# Altium schematic sheet [11] - DIGITAL OUTPUT.SchDoc("[11] - DIGITAL OUTPUT")
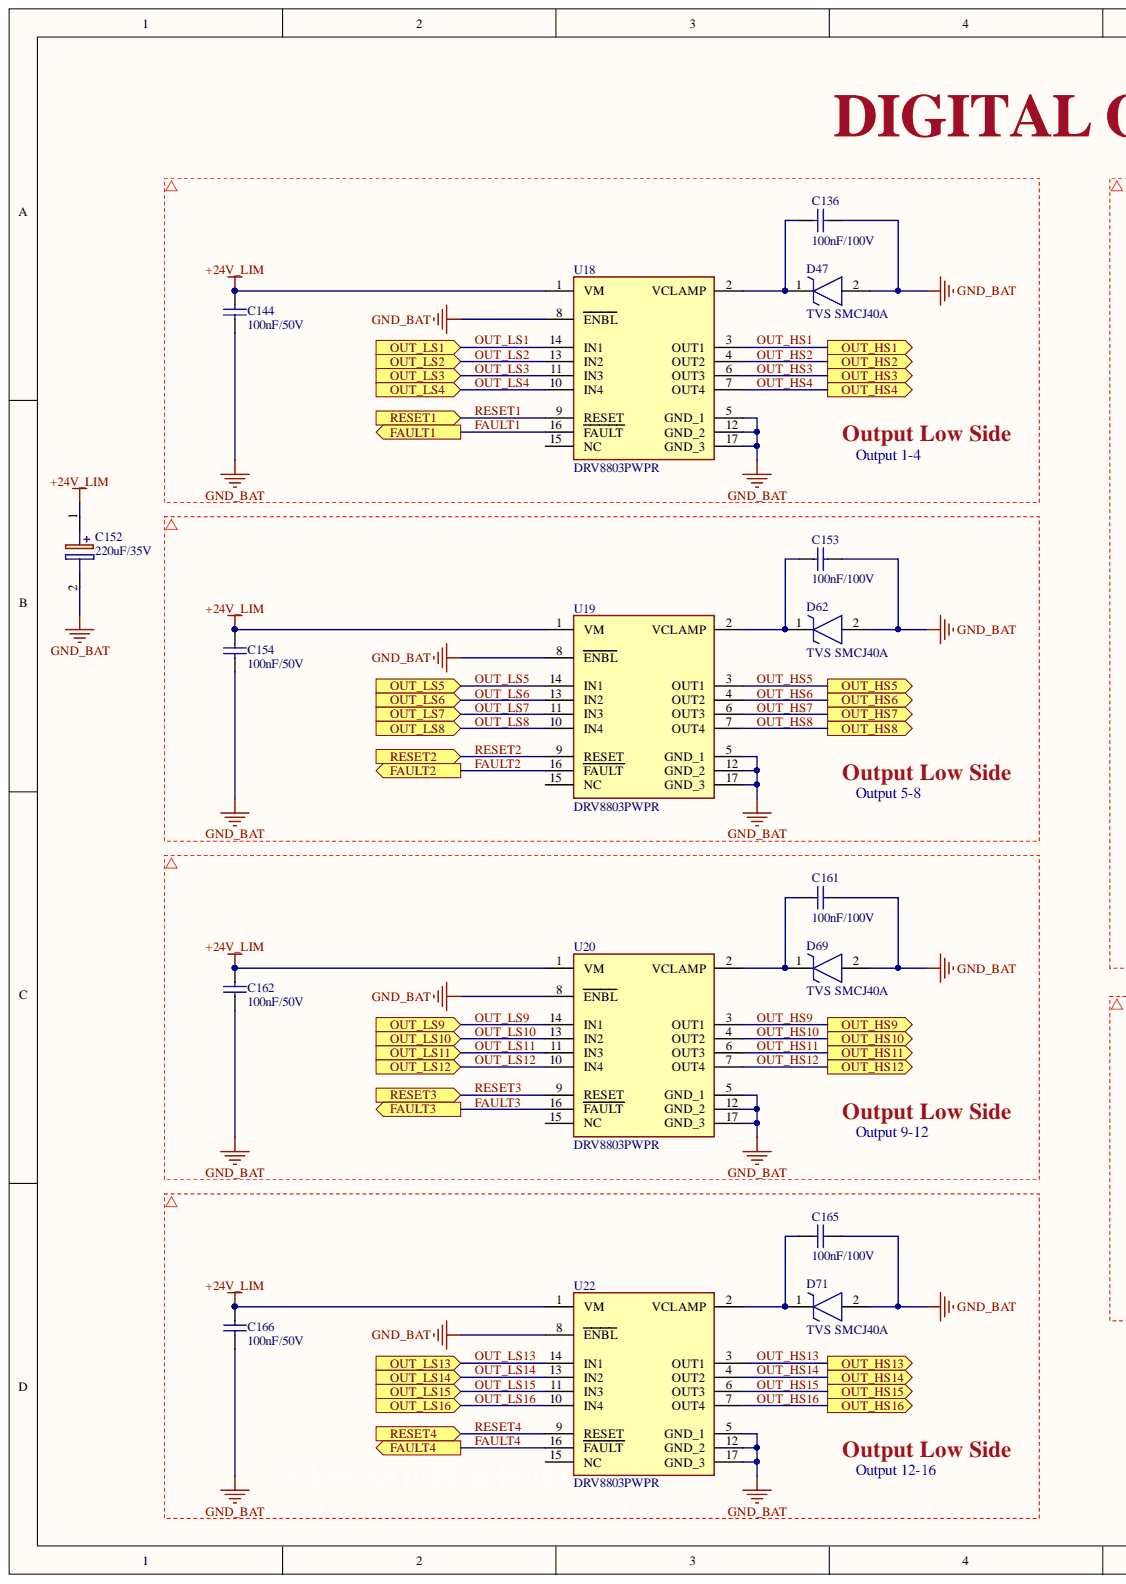
[diagram p0: [11] - DIGITAL OUTPUT.SchDoc, left]
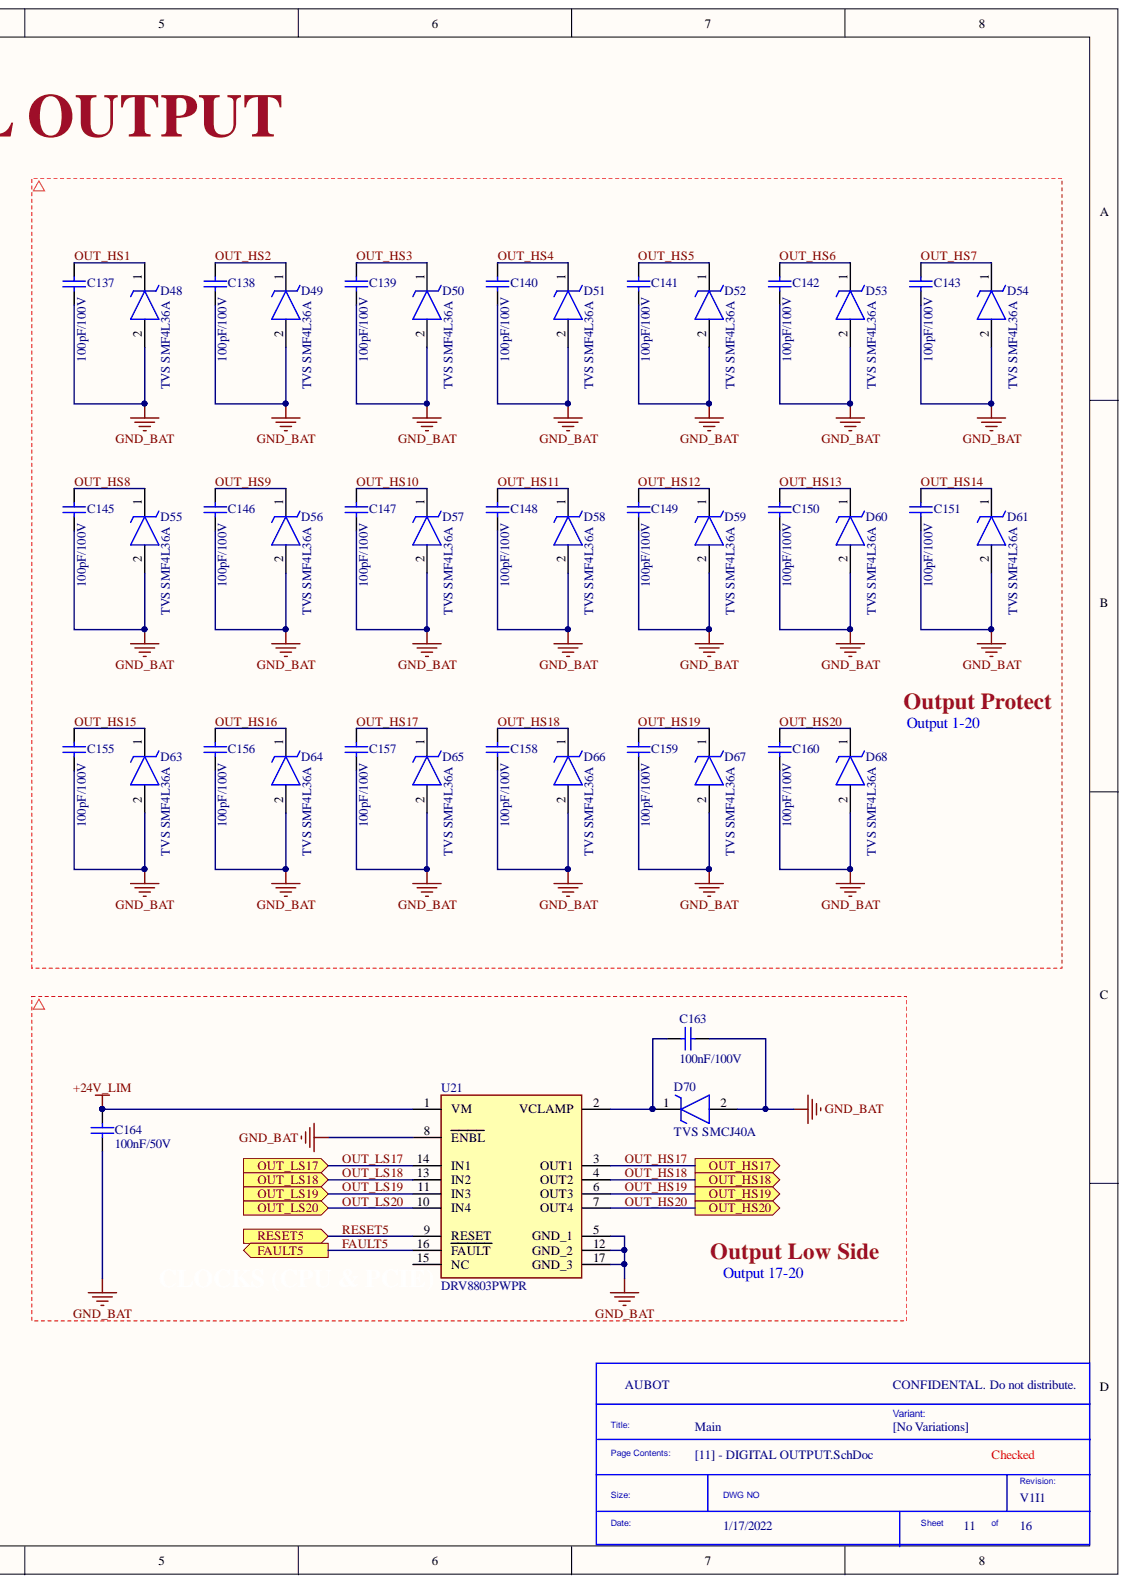
[diagram p1: [11] - DIGITAL OUTPUT.SchDoc, right]

## Parts
C136  100nF/100V  [CAP 0805]
C137  100pF/100V  [CAP 0805]
C138  100pF/100V  [CAP 0805]
C139  100pF/100V  [CAP 0805]
C140  100pF/100V  [CAP 0805]
C141  100pF/100V  [CAP 0805]
C142  100pF/100V  [CAP 0805]
C143  100pF/100V  [CAP 0805]
C144  100nF/50V  [CAP 0805]
C145  100pF/100V  [CAP 0805]
C146  100pF/100V  [CAP 0805]
C147  100pF/100V  [CAP 0805]
C148  100pF/100V  [CAP 0805]
C149  100pF/100V  [CAP 0805]
C150  100pF/100V  [CAP 0805]
C151  100pF/100V  [CAP 0805]
C152  220uF/35V  [CAP 10x16]
C153  100nF/100V  [CAP 0805]
C154  100nF/50V  [CAP 0805]
C155  100pF/100V  [CAP 0805]
C156  100pF/100V  [CAP 0805]
C157  100pF/100V  [CAP 0805]
C158  100pF/100V  [CAP 0805]
C159  100pF/100V  [CAP 0805]
C160  100pF/100V  [CAP 0805]
C161  100nF/100V  [CAP 0805]
C162  100nF/50V  [CAP 0805]
C163  100nF/100V  [CAP 0805]
C164  100nF/50V  [CAP 0805]
C165  100nF/100V  [CAP 0805]
C166  100nF/50V  [CAP 0805]
D47  TVS SMCJ40A  Manufacturer Part Number: SMCJ40A  [TVS SMCJ]
D48  TVS SMF4L36A  Manufacturer Part Number: SMF4L36A  [SOD-123-2 TVS]
D49  TVS SMF4L36A  Manufacturer Part Number: SMF4L36A  [SOD-123-2 TVS]
D50  TVS SMF4L36A  Manufacturer Part Number: SMF4L36A  [SOD-123-2 TVS]
D51  TVS SMF4L36A  Manufacturer Part Number: SMF4L36A  [SOD-123-2 TVS]
D52  TVS SMF4L36A  Manufacturer Part Number: SMF4L36A  [SOD-123-2 TVS]
D53  TVS SMF4L36A  Manufacturer Part Number: SMF4L36A  [SOD-123-2 TVS]
D54  TVS SMF4L36A  Manufacturer Part Number: SMF4L36A  [SOD-123-2 TVS]
D55  TVS SMF4L36A  Manufacturer Part Number: SMF4L36A  [SOD-123-2 TVS]
D56  TVS SMF4L36A  Manufacturer Part Number: SMF4L36A  [SOD-123-2 TVS]
D57  TVS SMF4L36A  Manufacturer Part Number: SMF4L36A  [SOD-123-2 TVS]
D58  TVS SMF4L36A  Manufacturer Part Number: SMF4L36A  [SOD-123-2 TVS]
D59  TVS SMF4L36A  Manufacturer Part Number: SMF4L36A  [SOD-123-2 TVS]
D60  TVS SMF4L36A  Manufacturer Part Number: SMF4L36A  [SOD-123-2 TVS]
D61  TVS SMF4L36A  Manufacturer Part Number: SMF4L36A  [SOD-123-2 TVS]
D62  TVS SMCJ40A  Manufacturer Part Number: SMCJ40A  [TVS SMCJ]
D63  TVS SMF4L36A  Manufacturer Part Number: SMF4L36A  [SOD-123-2 TVS]
D64  TVS SMF4L36A  Manufacturer Part Number: SMF4L36A  [SOD-123-2 TVS]
D65  TVS SMF4L36A  Manufacturer Part Number: SMF4L36A  [SOD-123-2 TVS]
D66  TVS SMF4L36A  Manufacturer Part Number: SMF4L36A  [SOD-123-2 TVS]
D67  TVS SMF4L36A  Manufacturer Part Number: SMF4L36A  [SOD-123-2 TVS]
D68  TVS SMF4L36A  Manufacturer Part Number: SMF4L36A  [SOD-123-2 TVS]
D69  TVS SMCJ40A  Manufacturer Part Number: SMCJ40A  [TVS SMCJ]
D70  TVS SMCJ40A  Manufacturer Part Number: SMCJ40A  [TVS SMCJ]
D71  TVS SMCJ40A  Manufacturer Part Number: SMCJ40A  [TVS SMCJ]
U18  DRV8803PWPR  [HTSSOP-16]
U19  DRV8803PWPR  [HTSSOP-16]
U20  DRV8803PWPR  [HTSSOP-16]
U21  DRV8803PWPR  [HTSSOP-16]
U22  DRV8803PWPR  [HTSSOP-16]

## Ports
FAULT1, FAULT2, FAULT3, FAULT4, FAULT5, OUT_HS1, OUT_HS2, OUT_HS3, OUT_HS4, OUT_HS5, OUT_HS6, OUT_HS7, OUT_HS8, OUT_HS9, OUT_HS10, OUT_HS11, OUT_HS12, OUT_HS13, OUT_HS14, OUT_HS15, OUT_HS16, OUT_HS17, OUT_HS18, OUT_HS19, OUT_HS20, OUT_LS1, OUT_LS2, OUT_LS3, OUT_LS4, OUT_LS5, OUT_LS6, OUT_LS7, OUT_LS8, OUT_LS9, OUT_LS10, OUT_LS11, OUT_LS12, OUT_LS13, OUT_LS14, OUT_LS15, OUT_LS16, OUT_LS17, OUT_LS18, OUT_LS19, OUT_LS20, RESET1, RESET2, RESET3, RESET4, RESET5

## Nets
+24V_LIM: C144.2 C152.1 C154.2 C162.2 C164.2 C166.2 U18.1(VM) U19.1(VM) U20.1(VM) U21.1(VM) U22.1(VM)
GND_BAT: C136.1 C137.1 C138.1 C139.1 C140.1 C141.1 C142.1 C143.1 C144.1 C145.1 C146.1 C147.1 C148.1 C149.1 C150.1 C151.1 C152.2 C153.1 C154.1 C155.1 C156.1 C157.1 C158.1 C159.1 C160.1 C161.1 C162.1 C163.1 C164.1 C165.1 C166.1 D47.2 D48.2 D49.2 D50.2 D51.2 D52.2 D53.2 D54.2 D55.2 D56.2 D57.2 D58.2 D59.2 D60.2 D61.2 D62.2 D63.2 D64.2 D65.2 D66.2 D67.2 D68.2 D69.2 D70.2 D71.2 U18.5(GND_1) U18.8(ENBL) U18.12(GND_2) U18.17(GND_3) U19.5(GND_1) U19.8(ENBL) U19.12(GND_2) U19.17(GND_3) U20.5(GND_1) U20.8(ENBL) U20.12(GND_2) U20.17(GND_3) U21.5(GND_1) U21.8(ENBL) U21.12(GND_2) U21.17(GND_3) U22.5(GND_1) U22.8(ENBL) U22.12(GND_2) U22.17(GND_3)
FAULT1: U18.16(FAULT) ; port FAULT1
FAULT2: U19.16(FAULT) ; port FAULT2
FAULT3: U20.16(FAULT) ; port FAULT3
FAULT4: U22.16(FAULT) ; port FAULT4
FAULT5: U21.16(FAULT) ; port FAULT5
OUT_HS1: C137.2 D48.1 U18.3(OUT1) ; port OUT_HS1
OUT_HS2: C138.2 D49.1 U18.4(OUT2) ; port OUT_HS2
OUT_HS3: C139.2 D50.1 U18.6(OUT3) ; port OUT_HS3
OUT_HS4: C140.2 D51.1 U18.7(OUT4) ; port OUT_HS4
OUT_HS5: C141.2 D52.1 U19.3(OUT1) ; port OUT_HS5
OUT_HS6: C142.2 D53.1 U19.4(OUT2) ; port OUT_HS6
OUT_HS7: C143.2 D54.1 U19.6(OUT3) ; port OUT_HS7
OUT_HS8: C145.2 D55.1 U19.7(OUT4) ; port OUT_HS8
OUT_HS9: C146.2 D56.1 U20.3(OUT1) ; port OUT_HS9
OUT_HS10: C147.2 D57.1 U20.4(OUT2) ; port OUT_HS10
OUT_HS11: C148.2 D58.1 U20.6(OUT3) ; port OUT_HS11
OUT_HS12: C149.2 D59.1 U20.7(OUT4) ; port OUT_HS12
OUT_HS13: C150.2 D60.1 U22.3(OUT1) ; port OUT_HS13
OUT_HS14: C151.2 D61.1 U22.4(OUT2) ; port OUT_HS14
OUT_HS15: C155.2 D63.1 U22.6(OUT3) ; port OUT_HS15
OUT_HS16: C156.2 D64.1 U22.7(OUT4) ; port OUT_HS16
OUT_HS17: C157.2 D65.1 U21.3(OUT1) ; port OUT_HS17
OUT_HS18: C158.2 D66.1 U21.4(OUT2) ; port OUT_HS18
OUT_HS19: C159.2 D67.1 U21.6(OUT3) ; port OUT_HS19
OUT_HS20: C160.2 D68.1 U21.7(OUT4) ; port OUT_HS20
OUT_LS1: U18.14(IN1) ; port OUT_LS1
OUT_LS2: U18.13(IN2) ; port OUT_LS2
OUT_LS3: U18.11(IN3) ; port OUT_LS3
OUT_LS4: U18.10(IN4) ; port OUT_LS4
OUT_LS5: U19.14(IN1) ; port OUT_LS5
OUT_LS6: U19.13(IN2) ; port OUT_LS6
OUT_LS7: U19.11(IN3) ; port OUT_LS7
OUT_LS8: U19.10(IN4) ; port OUT_LS8
OUT_LS9: U20.14(IN1) ; port OUT_LS9
OUT_LS10: U20.13(IN2) ; port OUT_LS10
OUT_LS11: U20.11(IN3) ; port OUT_LS11
OUT_LS12: U20.10(IN4) ; port OUT_LS12
OUT_LS13: U22.14(IN1) ; port OUT_LS13
OUT_LS14: U22.13(IN2) ; port OUT_LS14
OUT_LS15: U22.11(IN3) ; port OUT_LS15
OUT_LS16: U22.10(IN4) ; port OUT_LS16
OUT_LS17: U21.14(IN1) ; port OUT_LS17
OUT_LS18: U21.13(IN2) ; port OUT_LS18
OUT_LS19: U21.11(IN3) ; port OUT_LS19
OUT_LS20: U21.10(IN4) ; port OUT_LS20
RESET1: U18.9(RESET) ; port RESET1
RESET2: U19.9(RESET) ; port RESET2
RESET3: U20.9(RESET) ; port RESET3
RESET4: U22.9(RESET) ; port RESET4
RESET5: U21.9(RESET) ; port RESET5
NetC136_2: C136.2 D47.1 U18.2(VCLAMP)
NetC153_2: C153.2 D62.1 U19.2(VCLAMP)
NetC161_2: C161.2 D69.1 U20.2(VCLAMP)
NetC163_2: C163.2 D70.1 U21.2(VCLAMP)
NetC165_2: C165.2 D71.1 U22.2(VCLAMP)

Not connected: U18 15(NC); U19 15(NC); U20 15(NC); U21 15(NC); U22 15(NC)

## Library data of the parts
C136 C153 C161 C163 C165 = Cap 0805 100nF/100V: 0805 0.1 uF 100 V ±10% Tolerance X7R Multilayer Ceramic Chip Capacitor; Manufacturer Part Number: CC0805KKX7R0BB104; Manufacturer: Yageo; Voltage Rating (DC): 100V; Capacitance: 100nF; Width: 0.049inch; Weight: 0.000194oz; Voltage Rating: 100V; Voltage: 100V; Tolerance: 10%; Thickness: 0.028inch; Termination: SMD/SMT; Supplier Part Number 1: 311-2083-1-ND; Supplier 1: Digi-Key; Stock 1: 538; Series: CC; RoHS Compliant: Yes; RoHS: Yes; Resistor Type: Ceramic; Radiation Hardening: No; Pricing 1: 1 = 0.21000, 10 = 0.15200, 50 = 0.08600, 100 = 0.07130, 500 = 0.04998, 1000 = 0.04138 (USD); Packaging: Tape and Reel; Mount: Surface Mount; Min Operating Temperature: -55°C; Max Operating Temperature: 125°C; Length: 2mm; Lead Free: Lead Free; Dielectric: X7R; Depth: 1.25mm; Construction: Flat; ComponentLink1URL: https://datasheet.ciiva.com/17434/upy-np0x7r-mv-100-to-630v-9-17434762.pdf?src-supplier=Avnet+Europe; ComponentLink1Description: https://datasheet.ciiva.com/17434/upy-np0x7r-mv-100-to-630v-9-17434762.pdf?src-supplier=Avnet+Europe; Component Kind: Standard; Case Code (Metric): 2012; Case Code (Imperial): 0805; Case/Package: 0805; Library Name: Hieu_Lib.IntLib
C137-C143 C145-C151 C155-C160 = Cap 0805 100pF/100V: Cap Ceramic 100pF 100V X7R 10% Pad SMD 0805 125C T/R; Manufacturer Part Number: 0805B101K101CT; Manufacturer: Walsin Technologies; Tolerance: 10%; Supplier Part Number 1: 791-0805B101K101CT; Supplier 1: Mouser; Stock 1: 10942; RoHS Compliant: Yes; RoHS: Yes; Pricing 1: 1 = 0.50000, 10 = 0.29000, 50 = 0.29000, 100 = 0.08000, 1000 = 0.06000, 10000 = 0.05700 (USD); Packaging: Tape and Reel; Min Operating Temperature: -55°C; Max Operating Temperature: 125°C; Length: 2mm; Dielectric: X7R; Depth: 1.25mm; ComponentLink1URL: http://components.arrow.com/part/dataview/0323_00650654?src-supplier=Arrow+Europe; ComponentLink1Description: http://components.arrow.com/part/dataview/0323_00650654?src-supplier=Arrow+Europe; Component Kind: Standard; Case/Package: 0805; Capacitance: 100pF; Library Name: Hieu_Lib.IntLib
C144 C154 C162 C164 C166 = Cap 0805 100nF/50V: Ceramic capacitor SMD-0805 100nF /50V X7R# K +-10%, Samsung, RoHS; Manufacturer Part Number: CL21B104KBCNNNC; Voltage Rating (DC): 50V; Tolerance: 10%; Dielectric: X7R; Width: 0.049inch; Voltage Rating: 50V; Voltage: 50V; Thickness: 0.037inch; Supplier Part Number 1: C1711; Supplier 1: LCSC; Stock 1: 447650; Series: CL; RoHS Compliant: Yes; RoHS: Yes; Radiation Hardening: No; Pricing 1: 50 = 0.04090, 500 = 0.03130, 1500 = 0.02890, 5000 = 0.02580, 25000 = 0.02430, 50000 = 0.02410, 50 = 0.03950, 500 = 0.03020, 1500 = 0.02790, 5000 = 0.02490, 25000 = 0.02350, 50000 = 0.02330, 50 = 0.20680, 500 = 0.15820, 1500 = 0.14600, 5000 = 0.13020, 25000 = 0.12290, 50000 = 0.12170, 50 = 0.19640, 500 = 0.15020, 1500 = 0.13860, 5000 = 0.12360, 25000 = 0.11670, 50000 = 0.11550, 50 = 0.02640, 500 = 0.02020, 1500 = 0.01860, 5000 = 0.01660, 25000 = 0.01570, 50000 = 0.01550, 50 = 0.02300, 500 = 0.01760, 1500 = 0.01620, 5000 = 0.01440, 25000 = 0.01360, 50000 = 0.01350, 50 = 0.24740, 500 = 0.18920, 1500 = 0.17470, 5000 = 0.15570, 25000 = 0.14700, 50000 = 0.14560, 50 = 3.45220, 500 = 2.64000, 1500 = 2.43700, 5000 = 2.17300, 25000 = 2.05110, 50000 = 2.03080, 50 = 0.13070, 500 = 0.09990, 1500 = 0.09220, 5000 = 0.08230, 25000 = 0.07760, 50000 = 0.07690, 50 = 2.39050, 500 = 1.82810, 1500 = 1.68750, 5000 = 1.50470, 25000 = 1.42030, 50000 = 1.40620, 50 = 0.26740, 500 = 0.20450, 1500 = 0.18870, 5000 = 0.16830, 25000 = 0.15890, 50000 = 0.15730, 50 = 0.04230, 500 = 0.03230, 1500 = 0.02990, 5000 = 0.02660, 25000 = 0.02510, 50000 = 0.02490, 50 = 0.03188, 500 = 0.02438, 1500 = 0.02251, 5000 = 0.02007, 25000 = 0.01894, 50000 = 0.01875 (AUD); Packaging: Tape and Reel; Mount: Surface Mount; Min Operating Temperature: -55°C; Max Operating Temperature: 125°C; Material: Ceramic; Manufacturer: Samsung; Length: 2mm; Lead Free: Lead Free; Height: 0.85mm; Depth: 1.25mm; Contact Plating: Tin; Construction: Flat; ComponentLink2URL: https://datasheet.ciiva.com/29199/454152-da-01-en-vs-kondensator-0805-29199814.pdf?src-supplier=Conrad; ComponentLink2Description: https://datasheet.ciiva.com/29199/454152-da-01-en-vs-kondensator-0805-29199814.pdf?src-supplier=Conrad; ComponentLink1URL: https://datasheet.ciiva.com/25710/cl21b104kbcnnnc-25710182.pdf?src-supplier=Digi-Key; ComponentLink1Description: https://datasheet.ciiva.com/25710/cl21b104kbcnnnc-25710182.pdf?src-supplier=Digi-Key; Component Kind: Standard; Case Code (Metric): 2012; Case Code (Imperial): 0805; Case/Package: 0805; Capacitance: 100nF; Library Name: Hieu_Lib.IntLib
C152 = Cap Aluminum 220uF/35V: Cap Aluminum Lytic 220uF 35V 20% (10 X 16mm) Radial 5mm 825mA 3000h 105°C Bulk; Manufacturer Part Number: ELXV350EC5221MJ16S; Manufacturer: United Chemi-Con; Life (Hours): 3000Hour; Impedance: 84mR; Voltage Rating: 35V; Tolerance: 20%; Termination: Radial; Supplier Part Number 1: 661-ELXV350EC5221MJ1; Supplier 1: Mouser; Stock 1: 1849; Series: LXV; RoHS Compliant: Yes; RoHS: Yes; Ripple Current: 825mA; Pricing 1: 1 = 0.79000, 10 = 0.58800, 50 = 0.58800, 100 = 0.40300, 1000 = 0.26900, 10000 = 0.23500 (USD); Packaging: Bulk; Package Quantity: 1500; Mount: Through Hole; Min Operating Temperature: -55°C; Max Operating Temperature: 105°C; Length: 16mm; Lead Pitch: 5mm; Height - Seated (Max): 0.688inch; ComponentLink3URL: http://www.chemi-con.co.jp/cgi-bin/CAT_DB/SEARCH/cat_db_al.cgi?e=e&j=p&pdfname=lxv&src-supplier=Digi-Key; ComponentLink3Description: http://www.chemi-con.co.jp/cgi-bin/CAT_DB/SEARCH/cat_db_al.cgi?e=e&j=p&pdfname=lxv&src-supplier=Digi-Key; ComponentLink2URL: http://www.mouser.com/ds/2/420/18143430614d9b17398ecab-283889.pdf?src-supplier=Mouser; ComponentLink2Description: http://www.mouser.com/ds/2/420/18143430614d9b17398ecab-283889.pdf?src-supplier=Mouser; ComponentLink1URL: http://www.onlinecomponents.com/datasheet/elxv350ec5221mj16s.aspx?p=39080531&src-supplier=Onlinecomponents.com; ComponentLink1Description: http://www.onlinecomponents.com/datasheet/elxv350ec5221mj16s.aspx?p=39080531&src-supplier=Onlinecomponents.com; Component Kind: Standard; Case/Package: Radial; Capacitance: 220uF; Library Name: Hieu_Lib.IntLib
D47 D62 D69-D71 = TVS SMCJ40A: Diode TVS Single Uni-Dir 40V 1.5KW 2-Pin DO-214AB; Manufacturer: Bourns; Test Current: 1mA; Reverse Breakdown Voltage: 44.4V; Power Line Protection: No; Polarity: Unidirectional; Peak Pulse Current: 23.3A; Operating Supply Voltage: 3.5V; Number of Unidirectional Channels: 1; Max Surge Current: 200A; Max Reverse Leakage Current: 5uA; Diode Type: ZENER; Clamping Voltage: 64.5V; Breakdown Voltage: 51.1V; Termination: SMD/SMT; Supplier Part Number 1: 652-SMCJ40A; Supplier 1: Mouser; Stock 1: 23608; Series: SMCJ; RoHS Compliant: Yes; RoHS: Yes; Reverse Standoff Voltage: 40V; Resistor Type: Zener; Radiation Hardening: No; Pricing 1: 1 = 0.56000, 10 = 0.48200, 50 = 0.48200, 100 = 0.36900, 1000 = 0.24000, 10000 = 0.22600 (USD); Peak Pulse Power: 1.5kW; Packaging: Tape and Reel; Package Quantity: 1; Number of Pins: 2; Number of Elements: 1; Number of Channels: 1; Mount: Surface Mount; Min Operating Temperature: -55°C; Min Breakdown Voltage: 44.4V; Max Operating Temperature: 150°C; Leakage Current: 5uA; Element Configuration: Single; Direction: Unidirectional; ComponentLink7URL: https://datasheet.ciiva.com/336/smcj-336028.pdf?src-supplier=Digi-Key; ComponentLink7Description: https://datasheet.ciiva.com/336/smcj-336028.pdf?src-supplier=Digi-Key; ComponentLink6URL: https://datasheet.ciiva.com/336/smcj-336027.pdf?src-supplier=Digi-Key; ComponentLink6Description: https://datasheet.ciiva.com/336/smcj-336027.pdf?src-supplier=Digi-Key; ComponentLink5URL: https://datasheet.ciiva.com/336/smcj-336026.pdf?src-supplier=Digi-Key; ComponentLink5Description: https://datasheet.ciiva.com/336/smcj-336026.pdf?src-supplier=Digi-Key; ComponentLink4URL: https://datasheet.ciiva.com/3022/smcj-3022833.pdf?src-supplier=Avnet; ComponentLink4Description: https://datasheet.ciiva.com/3022/smcj-3022833.pdf?src-supplier=Avnet; ComponentLink3URL: https://datasheet.ciiva.com/17413/smcj-17413357.pdf?src-supplier=Avnet+Europe; ComponentLink3Description: https://datasheet.ciiva.com/17413/smcj-17413357.pdf?src-supplier=Avnet+Europe; ComponentLink2URL: https://datasheet.ciiva.com/3022/smcj-3022832.pdf?src-supplier=Avnet; ComponentLink2Description: https://datasheet.ciiva.com/3022/smcj-3022832.pdf?src-supplier=Avnet; ComponentLink1URL: https://datasheet.ciiva.com/26787/smcj40a-26787424.pdf?src-supplier=IIIC; ComponentLink1Description: https://datasheet.ciiva.com/26787/smcj40a-26787424.pdf?src-supplier=IIIC; Component Kind: Standard; Case/Package: DO-214AB; Library Name: Hieu_Lib.IntLib
D48-D61 D63-D68 = TVS SMF4L36A: TVS Diode Single Uni-Dir 36V 400W 2-Pin SOD-123FL T/R; Manufacturer: Littelfuse; Power Line Protection: No; Peak Pulse Current: 6.9A; Number of Unidirectional Channels: 1; Min Breakdown Voltage: 40V; Clamping Voltage: 58.1V; Supplier Part Number 1: F7485CT-ND; Supplier 1: Digi-Key; Stock 1: 6824; RoHS: Yes; Reverse Standoff Voltage: 36V; Resistor Type: Zener; Pricing 1: 1 = 0.46000, 10 = 0.37300, 100 = 0.25400, 500 = 0.19052, 1000 = 0.14288 (USD); Peak Pulse Power: 400W; Packaging: Cut Tape; Mount: Surface Mount; Min Operating Temperature: -55°C; Max Operating Temperature: 150°C; ComponentLink1URL: https://www.littelfuse.com/~/media/electronics/datasheets/tvs_diodes/littelfuse_tvs_diode_smf4l_datasheet.pdf.pdf?src-supplier=Digi-Key; ComponentLink1Description: https://www.littelfuse.com/~/media/electronics/datasheets/tvs_diodes/littelfuse_tvs_diode_smf4l_datasheet.pdf.pdf?src-supplier=Digi-Key; Component Kind: Standard; Library Name: Hieu_Lib.IntLib
U18-U22 = DRV8803PWPR: 60-V, 2.3-A, quad low-side motor driver with PWM control 16-HTSSOP -40 to 125; Manufacturer: Texas Instruments; Supply Current: 1.6mA; Output Voltage: 60V; Output Current: 1A; Number of Outputs: 4; Min Supply Voltage: 8.2V; Logic Function: AND GATE; Interface: Parallel; Supplier Part Number 1: 595-DRV8803PWPR; Supplier 1: Mouser; Stock 1: 2721; Series: -; RoHS Compliant: Yes; RoHS: Yes; Radiation Hardening: No; Pricing 1: 1 = 3.81000, 10 = 3.43000, 50 = 3.24000, 100 = 2.81000, 1000 = 2.09000, 10000 = 2.09000 (USD); Packaging: Tape and Reel; Package Quantity: 1; Number of Pins: 16; Nominal Supply Current: 1.6mA; Mount: Surface Mount; Min Operating Temperature: -40°C; Max Supply Voltage: 60V; Max Operating Temperature: 125°C; Manufacturer Part Number: DRV8803PWPR; Lead Free: Lead Free; ComponentLink3URL: https://datasheet.ciiva.com/29599/drv8803-29599451.pdf?src-supplier=Digi-Key; ComponentLink3Description: https://datasheet.ciiva.com/29599/drv8803-29599451.pdf?src-supplier=Digi-Key; ComponentLink2URL: https://datasheet.ciiva.com/3230/drv8803-3230858.pdf?src-supplier=Avnet; ComponentLink2Description: https://datasheet.ciiva.com/3230/drv8803-3230858.pdf?src-supplier=Avnet; ComponentLink1URL: https://datasheet.ciiva.com/26941/getdatasheetpartid-643909-26941446.pdf?src-supplier=Verical; ComponentLink1Description: https://datasheet.ciiva.com/26941/getdatasheetpartid-643909-26941446.pdf?src-supplier=Verical; Component Kind: Standard; Case/Package: HTSSOP EP; Library Name: Hieu_Lib.SchLib
